# Altium schematic sheet Detection_Circuit_Sheet_01.SchDoc
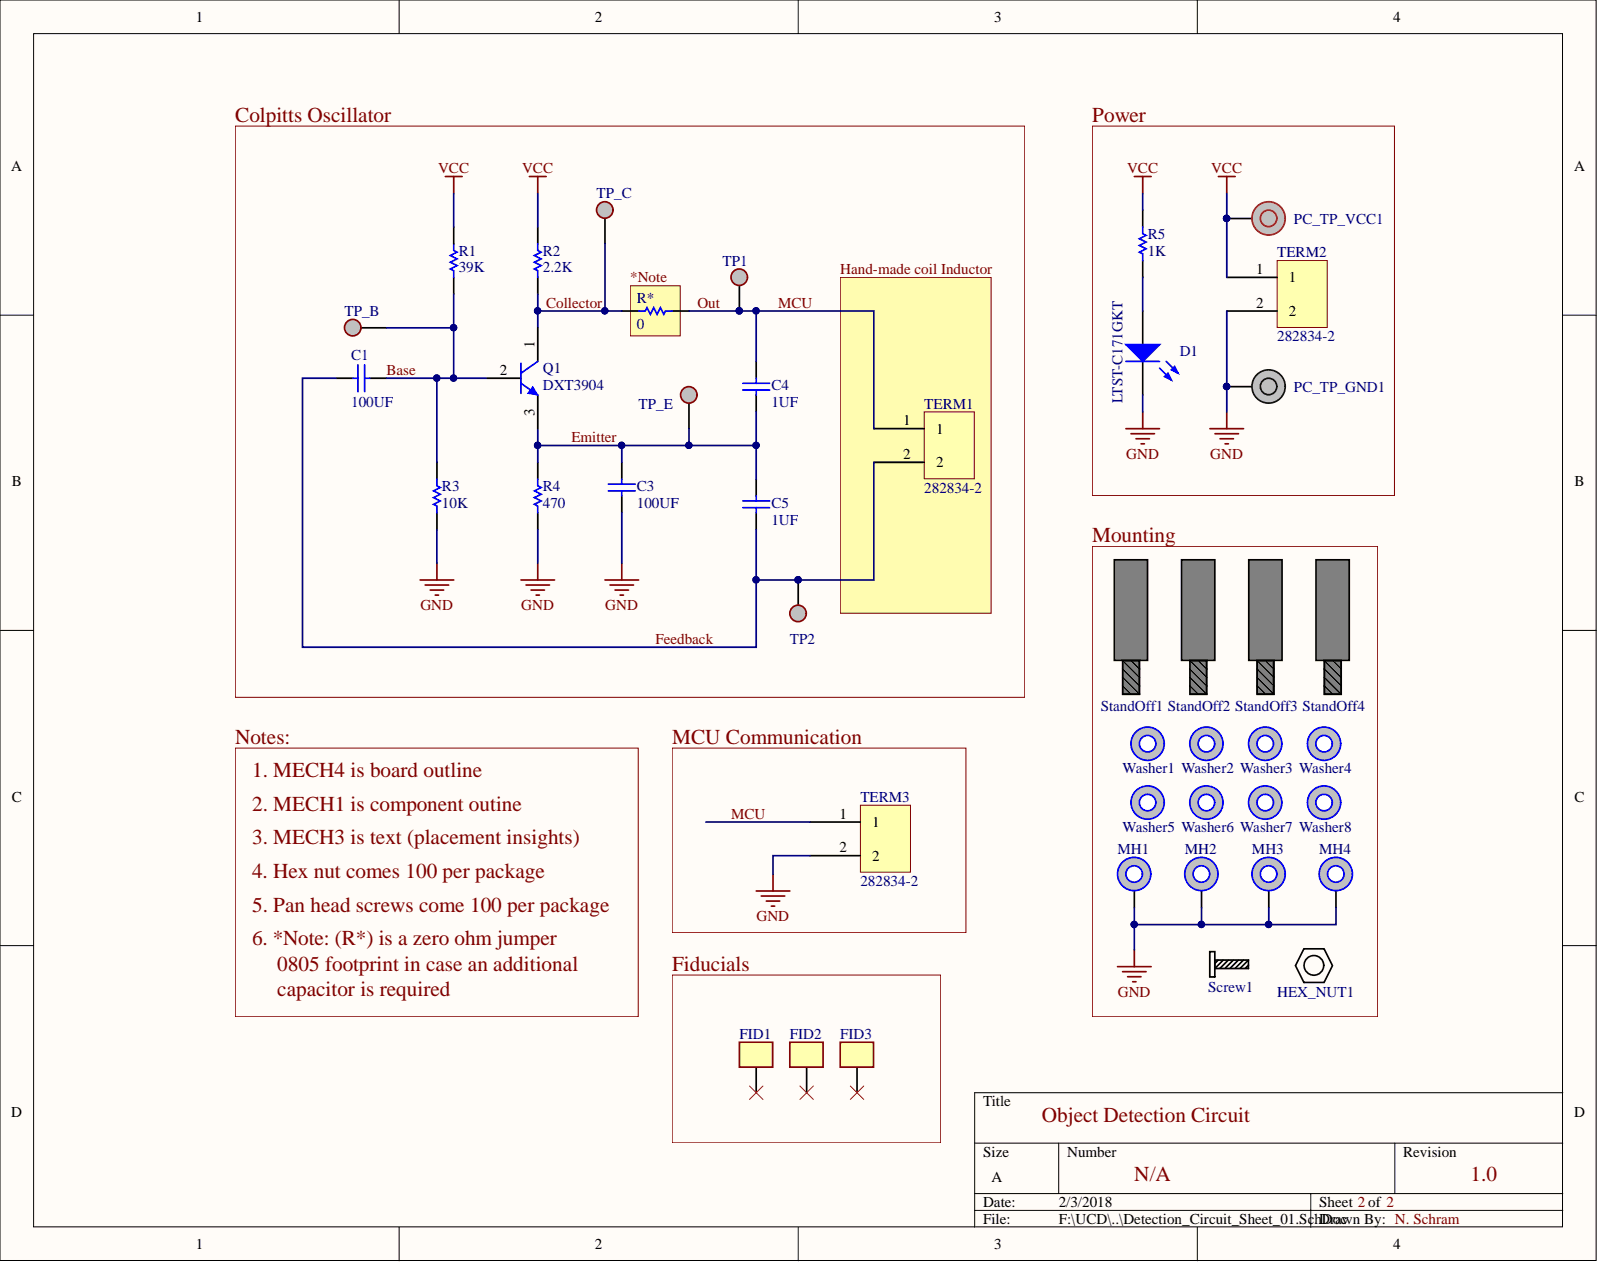
[diagram p0: Detection_Circuit_Sheet_01.SchDoc]

## Parts
C1  100UF  [C1210_M]
C3  100UF  [C1210_M]
C4  1UF  [6-0805_M]
C5  1UF  [6-0805_M]
D1  LTST-C171GKT  [6-0805_M]
FID1  Fiducial  [Fiducial]
FID2  Fiducial  [Fiducial]
FID3  Fiducial  [Fiducial]
HEX_NUT1  6-32 Hex Nut  (value not drawn)
MH1  #6 MH  [#6_MH]  (value not drawn)
MH2  #6 MH  [#6_MH]  (value not drawn)
MH3  #6 MH  [#6_MH]  (value not drawn)
MH4  #6 MH  [#6_MH]  (value not drawn)
PC_TP_GND1  PC Test Point GND  [PC Test Point GND]  (value not drawn)
PC_TP_VCC1  PC Test Point VCC  [PC Test Point VCC]  (value not drawn)
Q1  DXT3904  [DXT3904-13]
R1  39K  [6-0805_M]
R2  2.2K  [6-0805_M]
R3  10K  [6-0805_M]
R4  470  [6-0805_M]
R5  1K  [6-0805_M]
R*  0  [6-0805_M]
Screw1  6-32 Pan Head Screw  (value not drawn)
StandOff1  6-32 Stand Off  (value not drawn)
StandOff2  6-32 Stand Off  (value not drawn)
StandOff3  6-32 Stand Off  (value not drawn)
StandOff4  6-32 Stand Off  (value not drawn)
TERM1  282834-2  [282834-2]
TERM2  282834-2  [282834-2]
TERM3  282834-2  [282834-2]
TP1  TestPoint  [TestPoint]  (value not drawn)
TP2  TestPoint  [TestPoint]  (value not drawn)
TP_B  TestPoint  [TestPoint]  (value not drawn)
TP_C  TestPoint  [TestPoint]  (value not drawn)
TP_E  TestPoint  [TestPoint]  (value not drawn)
Washer1  Nylon Washer  (value not drawn)
Washer2  Nylon Washer  (value not drawn)
Washer3  Nylon Washer  (value not drawn)
Washer4  Nylon Washer  (value not drawn)
Washer5  Nylon Washer  (value not drawn)
Washer6  Nylon Washer  (value not drawn)
Washer7  Nylon Washer  (value not drawn)
Washer8  Nylon Washer  (value not drawn)

## Nets
GND: C3.1 D1.2 MH1.MH MH2.MH MH3.MH MH4.MH PC_TP_GND1.1 R3.1 R4.1 TERM2.2 TERM3.2
VCC: PC_TP_VCC1.1 R1.2 R2.2 R5.2 TERM2.1
Base: C1.2 Q1.2 R1.1 R3.2 TP_B.1
Collector: Q1.1 R2.1 R*.1 TP_C.1
Emitter: C3.2 C4.1 C5.2 Q1.3 R4.2 TP_E.1
Feedback: C1.1 C5.1 TERM1.2 TP2.1
MCU: C4.2 R*.2 TERM1.1 TERM3.1 TP1.1
NetD1_1: D1.1 R5.1

Not connected: FID1 FID; FID2 FID; FID3 FID

## Library data of the parts
C1 C3 = CC1210MKX5R7BB107: CAP CER 100UF 16V X5R 1210; Manufacturer: Yageo; Capacitance: 100µF; Tolerance: ±20%; Applications: General Purpose; Category: Capacitors; Component Kind: Standard; ComponentLink1Description: http://www.mouser.com/ds/2/447/Yageo_UPY-GP_NP0_16V-to-50V_9-358835.pdf; ComponentLink1URL: http://www.mouser.com/ds/2/447/Yageo_UPY-GP_NP0_16V-to-50V_9-358835.pdf; ComponentLink2Description: http://www.yageo.com/documents/recent/UPY-GPHC_X5R_4V-to-50V_25.pdf; ComponentLink2URL: http://www.yageo.com/documents/recent/UPY-GPHC_X5R_4V-to-50V_25.pdf; Family: Ceramic Capacitors; Features: -; Height - Seated (Max): -; Lead Spacing: -; Lead Style: -; Library Name: CC1210MKX5R7BB107.SchLib; Manufacturer Part Number: CC1210MKX5R7BB107; Mounting Type: Surface Mount, MLCC; Operating Temperature: -55°C ~ 85°C; Package / Case: 1210 (3225 Metric); Packaging: Tape & Reel (TR); Pricing 1: 1=1.82, 10=1.437, 100=1.0778 (USD); Ratings: -; Series: CC; Size / Dimension: 0.126" L x 0.098" W (3.20mm x 2.50mm); Stock 1: 19901; Supplier 1: Digi-Key; Supplier Part Number 1: 311-2052-1-ND; Temperature Coefficient: X5R; Thickness (Max): 0.110" (2.80mm); Voltage - Rated: 16V
C4 C5 = C0805C105J4RACTU: CAP CER 1UF 16V X7R 0805; Manufacturer: KEMET; Capacitance: 1µF; Online Catalog: X7R Dielectric (Commercial Grade); Other Names: 399-8001-6; Product Training Modules: Capacitor Basics- Typical Uses for Capacitors; Tolerance: ±5%; Applications: General Purpose; Category: Capacitors; Component Kind: Standard; ComponentLink1Description: http://components.arrow.com/part/dataview/30748390S8976888N7429; ComponentLink1URL: http://components.arrow.com/part/dataview/30748390S8976888N7429; ComponentLink2Description: http://www.mouser.com/ds/2/212/KEM_C1002_X7R_SMD-1102033.pdf; ComponentLink2URL: http://www.mouser.com/ds/2/212/KEM_C1002_X7R_SMD-1102033.pdf; ComponentLink3Description: http://www.farnell.com/datasheets/1828800.pdf; ComponentLink3URL: http://www.farnell.com/datasheets/1828800.pdf; ComponentLink4Description: http://www.farnell.com/datasheets/2064986.pdf; ComponentLink4URL: http://www.farnell.com/datasheets/2064986.pdf; ComponentLink5Description: http://capacitoredge.kemet.com/capedge2/DataSheet?pn=C0805C105J4RACTU; ComponentLink5URL: http://capacitoredge.kemet.com/capedge2/DataSheet?pn=C0805C105J4RACTU; ComponentLink6Description: https://search.kemet.com/component-edge/download/datasheet/C0805C105J4RACTU.pdf; ComponentLink6URL: https://search.kemet.com/component-edge/download/datasheet/C0805C105J4RACTU.pdf; Family: Ceramic Capacitors; Features: -; Height - Seated (Max): -; Lead Spacing: -; Lead Style: -; Library Name: C0805C105J4RACTU.SchLib; Manufacturer Part Number: C0805C105J4RACTU; Mounting Type: Surface Mount, MLCC; Operating Temperature: -55°C ~ 125°C; Package / Case: 0805 (2012 Metric); Packaging: Digi-Reel®; Pricing 1: 1=0.35, 10=0.241, 100=0.1364, 500=0.0963, 1000=0.0842 (USD); Ratings: -; Series: C; Size / Dimension: 0.079" L x 0.049" W (2.00mm x 1.25mm); Standard Package: 1; Stock 1: 96238; Supplier 1: Digi-Key; Supplier Part Number 1: 399-8001-1-ND; Temperature Coefficient: X7R; Thickness (Max): 0.039" (1.00mm); Voltage - Rated: 16V
D1 = LED3: Typical BLUE SiC LED; Manufacturer: Vishay Lite-On; Chip Material: GaP; DELETED: Compliant; Dominant Wave Length: 569 nm; Emitting Color: Yellow Green; Forward Current: 30 mA; Forward Voltage: 2.6 V; High Brightness: No; Luminous Intensity: 12mcd; Main Category: Chip LED; Number of  Elements: 1; Peak Wavelength: 565 nm; Power Dissipation: 100 W; Product Depth (mm): 1.25 mm; Product Height (mm): 0.8 mm; Product Length (mm): 2 mm; Reverse Voltage: 5 V; Shape Type: Rectangular; Test Current (It): 10 mA; Type: Uni-Color; Viewing Angle: 130 deg; Component Kind: Standard; ComponentLink1Description: https://datasheet.ciiva.com/2110/ltst-c171gkt-2110000.pdf; ComponentLink1URL: https://datasheet.ciiva.com/2110/ltst-c171gkt-2110000.pdf; ComponentLink2Description: https://datasheet.ciiva.com/2110/ltst-c171gkt-2110001.pdf; ComponentLink2URL: https://datasheet.ciiva.com/2110/ltst-c171gkt-2110001.pdf; ComponentLink3Description: https://datasheet.ciiva.com/2110/ltst-c171gkt-2110002.pdf; ComponentLink3URL: https://datasheet.ciiva.com/2110/ltst-c171gkt-2110002.pdf; ComponentLink4Description: https://datasheet.ciiva.com/16396/ltst-c171gkt-16396549.pdf; ComponentLink4URL: https://datasheet.ciiva.com/16396/ltst-c171gkt-16396549.pdf; ComponentLink5Description: https://datasheet.ciiva.com/11773/0900766b80dc0296-11773991.pdf; ComponentLink5URL: https://datasheet.ciiva.com/11773/0900766b80dc0296-11773991.pdf; ComponentLink6Description: https://datasheet.ciiva.com/12400/lite-on-ltst-c171gkt-190133-12400647.pdf; ComponentLink6URL: https://datasheet.ciiva.com/12400/lite-on-ltst-c171gkt-190133-12400647.pdf; Lens Dimensions: 1.25X1.4X0.5 mm; Library Name: Miscellaneous Devices.IntLib; Lum Intensity: 12mcd; Manufacturer Part Number: LTST-C171GKT; Mounting: Surface Mount; Operating Temperature Classification: Industrial; Operating Temp Range: -55C to 85C; Package Type: Chip Led; Packaging: Tape and Reel; Pin Count: 2; Pricing 1: 3000=0.0497, 6000=0.0432, 15000=0.0389, 30000=0.0346, 75000=0.0313, 150000=0.0292 (USD); Rad Hardened: No; Stock 1: 153000; Supplier 1: Digi-Key; Supplier Part Number 1: 160-1423-2-ND
HEX_NUT1 = HEX_NUT: HEX NUT 0.245" STEEL 6-32; Manufacturer Part Number: 4701; Brand: Keystone Electronics; Gender: Female; Plating: Zinc; Component Kind: Standard; ComponentLink1Description: https://datasheet.ciiva.com/41799/4701-41799174.pdf; ComponentLink1URL: https://datasheet.ciiva.com/41799/4701-41799174.pdf; ComponentLink2Description: https://datasheet.ciiva.com/18416/70181805-18416126.pdf; ComponentLink2URL: https://datasheet.ciiva.com/18416/70181805-18416126.pdf; Factory Pack Quantity: 100; Features: Universal Mounting Kits; Length: 6.22 mm; Library Name: 6-32_Hex_Nut.SchLib; Manufacturer: Keystone Electronics; Material: Steel; Pricing 1: 1=0.1, 10=0.049, 50=0.0342, 100=0.0293, 250=0.0283, 500=0.0244, 1000=0.0219, 2500=0.0195, 5000=0.0185 (USD); Product Category: Screws & Fasteners; RoHS: Details; Stock 1: 51671; Supplier 1: Digi-Key; Supplier Part Number 1: 36-4701-ND; Thickness: 2.41 mm; Thread Size: 6-32; Type: Nuts; Width: 6.22 mm
MH1-MH4 = MH: #6 Mounting Hole; Component Kind: Standard; Library Name: #6_MH.SchLib
PC_TP_GND1 = PC_TP_GND: TEST POINT PC MINI .040"D BLACK; Manufacturer: Keystone Electronics; Manufacturer Part Number: 5001; Alternate Color: 5004K-ND  - TEST POINT PC MINI .040"D YELLOW  5003K-ND  - TEST POINT PC MINI .040"D ORANGE  5002K-ND  - TEST POINT PC MINI .040"D WHITE  5000K-ND  - TEST POINT PC MINI .040"D RED; Catalog Drawings: 5000 Series; Kits: DKTGRS232C-KIT-ND  - TTL CONVERTER; Online Catalog: Color Coded Test Points; Other Names: 5001K; Category: Test and Measurement; Color: Black; Component Kind: Standard; ComponentLink1Description: https://datasheet.ciiva.com/27052/1581986-27052611.pdf; ComponentLink1URL: https://datasheet.ciiva.com/27052/1581986-27052611.pdf; ComponentLink2Description: https://datasheet.ciiva.com/16318/m60-2p60-16318423.pdf; ComponentLink2URL: https://datasheet.ciiva.com/16318/m60-2p60-16318423.pdf; ComponentLink3Description: https://datasheet.ciiva.com/16884/70182825-16884025.pdf; ComponentLink3URL: https://datasheet.ciiva.com/16884/70182825-16884025.pdf; ComponentLink4Description: https://datasheet.ciiva.com/22631/1703983-22631100.pdf; ComponentLink4URL: https://datasheet.ciiva.com/22631/1703983-22631100.pdf; ComponentLink5Description: https://datasheet.ciiva.com/11836/0900766b8009b7c7-11836045.pdf; ComponentLink5URL: https://datasheet.ciiva.com/11836/0900766b8009b7c7-11836045.pdf; Family: Test Points; Library Name: PC_TP_GND.SchLib; Material: Base, Nylon 46, UL 94V-0; Mounting Type: 0.040" (1.02mm) Hole Diameter; Packaging: Bulk; Pricing 1: 1=0.37, 10=0.316, 50=0.2298, 100=0.2209, 250=0.1983, 1000=0.1616, 2500=0.1442, 5000=0.1352 (USD); RoHS Information: RoHS Compliance Statement; Series: THM; Standard Package: 100; Stock 1: 888627; Supplier 1: Digi-Key; Supplier Part Number 1: 36-5001-ND; Terminal: 0.010" x 0.020" (0.25mm x 0.51mm) Phosphor Bronze Wire, Silver Plated; Type: PC Test Point, Miniature
PC_TP_VCC1 = PC_TP_VCC: TEST POINT PC MINI .040"D RED; Manufacturer: Keystone Electronics; Manufacturer Part Number: 5000; Alternate Color: 5004K-ND  - TEST POINT PC MINI .040"D YELLOW  5003K-ND  - TEST POINT PC MINI .040"D ORANGE  5002K-ND  - TEST POINT PC MINI .040"D WHITE  5001K-ND  - TEST POINT PC MINI .040"D BLACK; Catalog Drawings: 5000 Series; Online Catalog: Color Coded Test Points; Other Names: 5000K; Category: Test and Measurement; Color: Red; Component Kind: Standard; ComponentLink1Description: https://datasheet.ciiva.com/2917/5000-5004-2917627.pdf; ComponentLink1URL: https://datasheet.ciiva.com/2917/5000-5004-2917627.pdf; ComponentLink2Description: https://datasheet.ciiva.com/16883/70182825-16883965.pdf; ComponentLink2URL: https://datasheet.ciiva.com/16883/70182825-16883965.pdf; ComponentLink3Description: https://datasheet.ciiva.com/11836/0900766b8009b7c7-11836046.pdf; ComponentLink3URL: https://datasheet.ciiva.com/11836/0900766b8009b7c7-11836046.pdf; Family: Test Points; Library Name: PC_TP_VCC.SchLib; Material: Base, Nylon 46, UL 94V-0; Mounting Type: 0.040" (1.02mm) Hole Diameter; Packaging: Bulk; Pricing 1: 1=0.37, 10=0.316, 50=0.2298, 100=0.2209, 250=0.1983, 1000=0.1616, 2500=0.1442, 5000=0.1352 (USD); RoHS Information: RoHS Compliance Statement; Series: THM; Standard Package: 100; Stock 1: 652198; Supplier 1: Digi-Key; Supplier Part Number 1: 36-5000-ND; Terminal: 0.010" x 0.020" (0.25mm x 0.51mm) Phosphor Bronze Wire, Silver Plated; Type: PC Test Point, Miniature
Q1 = DXT3904-13: TRANS NPN 40V 0.2A SOT89-3; Manufacturer: Diodes; Brand: Diodes Incorporated; Collector- Base Voltage VCBO: 60 V; Collector-Emitter Saturation Voltage: 300 mV; Configuration: Single; DC Collector/Base Gain hfe Min: 30 at 100 mA, 1 V; Emitter- Base Voltage VEBO: 6 V; Gain Bandwidth Product fT: 300 MHz; Maximum DC Collector Current: 200 mA; Pd - Power Dissipation: 1000 mW; Unit Weight: 0.001834 oz; Collector- Emitter Voltage VCEO Max: 40 V; Component Kind: Standard; ComponentLink1Description: https://datasheet.ciiva.com/979/ds31141-979988.pdf; ComponentLink1URL: https://datasheet.ciiva.com/979/ds31141-979988.pdf; ComponentLink2Description: https://datasheet.ciiva.com/4724/ds31141-4724919.pdf; ComponentLink2URL: https://datasheet.ciiva.com/4724/ds31141-4724919.pdf; ComponentLink3Description: https://datasheet.ciiva.com/17303/ds31141-17303788.pdf; ComponentLink3URL: https://datasheet.ciiva.com/17303/ds31141-17303788.pdf; ComponentLink4Description: https://datasheet.ciiva.com/11206/ds31141-11206239.pdf; ComponentLink4URL: https://datasheet.ciiva.com/11206/ds31141-11206239.pdf; Factory Pack Quantity: 2500; Height: 1.5 mm; Length: 4.5 mm; Library Name: DXT3904-13.SchLib; Manufacturer Part Number: DXT3904-13; Maximum Operating Temperature: + 150 C; Minimum Operating Temperature: - 55 C; Mounting Style: SMD/SMT; Package / Case: SOT-89; Packaging: Reel; Pricing 1: 1=0.53, 10=0.426, 100=0.29, 500=0.2175, 1000=0.1632 (USD); Product Category: Bipolar Transistors - BJT; RoHS: True; Series: DXT3904; Stock 1: 10942; Supplier 1: Digi-Key; Supplier Part Number 1: DXT3904-13DICT-ND; Transistor Polarity: NPN; Width: 2.48 mm
R1 = ERJ-PB6D3902V: RES SMD 39K OHM 0.5% 1/4W 0805; Manufacturer: Panasonic; Power (Watts): 0.25W, 1/4W; Temperature Coefficient: ±50ppm/°C; Category: Resistors; Component Kind: Standard; ComponentLink1Description: https://industrial.panasonic.com/sa/system/files/data/download/catalog/id_fixed-resistors_e_2.pdf; ComponentLink1URL: https://industrial.panasonic.com/sa/system/files/data/download/catalog/id_fixed-resistors_e_2.pdf; Composition: Thick Film; Failure Rate: -; Family: Chip Resistor - Surface Mount; Features: Automotive AEC-Q200, Pulse Withstanding; Height - Seated (Max): 0.028" (0.70mm); Library Name: ERJ-PB6D3902V.SchLib; Manufacturer Part Number: ERJ-PB6D3902V; Number of Terminations: 2; Operating Temperature: -55°C ~ 155°C; Package / Case: 0805 (2012 Metric); Packaging: Tape & Reel (TR); Part Status: Active; Pricing 1: 1=0.24, 10=0.204, 25=0.1488, 50=0.1078, 100=0.0799, 250=0.0614, 500=0.0483, 1000=0.0335 (USD); Resistance (Ohms): 39k; Series: ERJ; Size / Dimension: 0.079" L x 0.049" W (2.00mm x 1.25mm); Stock 1: 2236; Supplier 1: Digi-Key; Supplier Device Package: 0805; Supplier Part Number 1: P21199CT-ND; Tolerance: ±0.5%
R2 = ERJ-PB6D2201V: RES SMD 2.2K OHM 0.5% 1/4W 0805; Manufacturer: Panasonic; Power (Watts): 0.25W, 1/4W; Temperature Coefficient: ±50ppm/°C; Category: Resistors; Component Kind: Standard; ComponentLink1Description: https://industrial.panasonic.com/sa/system/files/data/download/catalog/id_fixed-resistors_e_2.pdf; ComponentLink1URL: https://industrial.panasonic.com/sa/system/files/data/download/catalog/id_fixed-resistors_e_2.pdf; Composition: Thick Film; Failure Rate: -; Family: Chip Resistor - Surface Mount; Features: Automotive AEC-Q200, Pulse Withstanding; Height - Seated (Max): 0.028" (0.70mm); Library Name: ERJ-PB6D2201V.SchLib; Manufacturer Part Number: ERJ-PB6D2201V; Number of Terminations: 2; Operating Temperature: -55°C ~ 155°C; Package / Case: 0805 (2012 Metric); Packaging: Tape & Reel (TR); Part Status: Active; Pricing 1: 1=0.24, 10=0.204, 25=0.1488, 50=0.1078, 100=0.0799, 250=0.0614, 500=0.0483, 1000=0.0335 (USD); Resistance (Ohms): 2.2k; Series: ERJ; Size / Dimension: 0.079" L x 0.049" W (2.00mm x 1.25mm); Stock 1: 3147; Supplier 1: Digi-Key; Supplier Device Package: 0805; Supplier Part Number 1: P21055CT-ND; Tolerance: ±0.5%
R3 = RNCP0805FTD10K0: RES SMD 10K OHM 1% 1/4W 0805; Manufacturer: Stackpole Electronics; Power (Watts): 0.25W, 1/4W; Temperature Coefficient: ±100ppm/°C; Category: Resistors; Component Kind: Standard; ComponentLink1Description: http://www.seielect.com/Catalog/SEI-rncp.pdf; ComponentLink1URL: http://www.seielect.com/Catalog/SEI-rncp.pdf; Composition: Thin Film; Family: Chip Resistor - Surface Mount; Features: Anti-Sulfur, Moisture Resistant; Height: 0.024" (0.60mm); Library Name: RNCP0805FTD10K0.SchLib; Manufacturer Part Number: RNCP0805FTD10K0; Number of Terminations: 2; Operating Temperature: -55°C ~ 155°C; Package / Case: 0805 (2012 Metric); Packaging: Tape & Reel (TR); Pricing 1: 1=0.1, 10=0.037, 100=0.015, 1000=0.0067, 2500=0.0058 (USD); Resistance (Ohms): 10k; Series: RNCP; Size / Dimension: 0.079" L x 0.049" W (2.00mm x 1.25mm); Stock 1: 103823; Supplier 1: Digi-Key; Supplier Device Package: 0805; Supplier Part Number 1: RNCP0805FTD10K0CT-ND; Tolerance: ±1%
R4 = ERJ-PB6D4700V: RES SMD 470 OHM 0.5% 1/4W 0805; Manufacturer: Panasonic; Power (Watts): 0.25W, 1/4W; Temperature Coefficient: ±50ppm/°C; Category: Resistors; Component Kind: Standard; ComponentLink1Description: https://industrial.panasonic.com/sa/system/files/data/download/catalog/id_fixed-resistors_e_2.pdf; ComponentLink1URL: https://industrial.panasonic.com/sa/system/files/data/download/catalog/id_fixed-resistors_e_2.pdf; Composition: Thick Film; Failure Rate: -; Family: Chip Resistor - Surface Mount; Features: Automotive AEC-Q200, Pulse Withstanding; Height - Seated (Max): 0.028" (0.70mm); Library Name: ERJ-PB6D4700V.SchLib; Manufacturer Part Number: ERJ-PB6D4700V; Number of Terminations: 2; Operating Temperature: -55°C ~ 155°C; Package / Case: 0805 (2012 Metric); Packaging: Cut Tape (CT); Part Status: Active; Pricing 1: 1=0.24, 10=0.204, 25=0.1488, 50=0.1078, 100=0.0799, 250=0.0614, 500=0.0483, 1000=0.0335 (USD); Resistance (Ohms): 470; Series: ERJ; Size / Dimension: 0.079" L x 0.049" W (2.00mm x 1.25mm); Stock 1: 2688; Supplier 1: Digi-Key; Supplier Device Package: 0805; Supplier Part Number 1: P20981CT-ND; Tolerance: ±0.5%
R5 = RNCP0805FTD1K00: RES SMD 1K OHM 1% 1/4W 0805; Manufacturer: Stackpole Electronics; Power (Watts): 0.25W, 1/4W; Temperature Coefficient: ±100ppm/°C; Category: Resistors; Component Kind: Standard; ComponentLink1Description: http://www.seielect.com/Catalog/SEI-rncp.pdf; ComponentLink1URL: http://www.seielect.com/Catalog/SEI-rncp.pdf; Composition: Thin Film; Family: Chip Resistor - Surface Mount; Features: Anti-Sulfur, Moisture Resistant; Height: 0.024" (0.60mm); Library Name: RNCP0805FTD1K00.SchLib; Manufacturer Part Number: RNCP0805FTD1K00; Number of Terminations: 2; Operating Temperature: -55°C ~ 155°C; Package / Case: 0805 (2012 Metric); Packaging: Tape & Reel (TR); Pricing 1: 1=0.1, 10=0.037, 100=0.015, 1000=0.0067, 2500=0.0058 (USD); Resistance (Ohms): 1k; Series: RNCP; Size / Dimension: 0.079" L x 0.049" W (2.00mm x 1.25mm); Stock 1: 107354; Supplier 1: Digi-Key; Supplier Device Package: 0805; Supplier Part Number 1: RNCP0805FTD1K00CT-ND; Tolerance: ±1%
R* = ERJ-6GEY0R00V: RES SMD 0 OHM JUMPER 1/8W 0805; Manufacturer: Panasonic ECG; Rated Power: 0.125 W; Resistance: 0 O; Temp Coefficient: 0 ppm/°C; Tolerance (%): 0 %; Component Kind: Standard; ComponentLink1Description: https://datasheet.ciiva.com/28695/1678796-28695877.pdf; ComponentLink1URL: https://datasheet.ciiva.com/28695/1678796-28695877.pdf; ComponentLink2Description: https://datasheet.ciiva.com/11821/0900766b80f806dd-11821735.pdf; ComponentLink2URL: https://datasheet.ciiva.com/11821/0900766b80f806dd-11821735.pdf; ComponentLink3Description: https://datasheet.ciiva.com/43460/1678796-43460073.pdf; ComponentLink3URL: https://datasheet.ciiva.com/43460/1678796-43460073.pdf; ComponentLink4Description: https://datasheet.ciiva.com/9117/aoa0000ce1-9117354.pdf; ComponentLink4URL: https://datasheet.ciiva.com/9117/aoa0000ce1-9117354.pdf; ComponentLink5Description: https://datasheet.ciiva.com/46377/1678796-46377866.pdf; ComponentLink5URL: https://datasheet.ciiva.com/46377/1678796-46377866.pdf; ComponentLink6Description: https://datasheet.ciiva.com/36526/1989607-36526237.pdf; ComponentLink6URL: https://datasheet.ciiva.com/36526/1989607-36526237.pdf; ComponentLink7Description: https://datasheet.ciiva.com/17215/aoa0000ce1-17215390.pdf; ComponentLink7URL: https://datasheet.ciiva.com/17215/aoa0000ce1-17215390.pdf; ComponentLink8Description: https://datasheet.ciiva.com/16512/aoa0000ce1-16512111.pdf; ComponentLink8URL: https://datasheet.ciiva.com/16512/aoa0000ce1-16512111.pdf; Library Name: ERJ-6GEY0R00V.SchLib; Manufacturer Part Number: ERJ-6GEY0R00V; Pricing 1: 1=0.1, 10=0.053, 25=0.038, 50=0.029, 100=0.0214, 250=0.0163, 500=0.0131, 1000=0.0096 (USD); Size Code: 0805; Stock 1: 6840904; Supplier 1: Digi-Key; Supplier Part Number 1: P0.0ACT-ND
Screw1 = 6-32 Screw: MACHINE SCREW PAN PHILLIPS 6-32; Manufacturer: B&F Fastener; Manufacturer Part Number: PMSSS6320050PH; Dynamic Catalog: Stainless Steel Screws; Head Height: 0.092" (2.34mm); Kits: 1608-KIT-ND  - STAINLESS STL HARDWARE #6,#8,#10; Other Names: H711; Category: Hardware, Fasteners, Accessories; Component Kind: Standard; ComponentLink1Description: https://datasheet.ciiva.com/1034/machinepancombo-1034179.pdf; ComponentLink1URL: https://datasheet.ciiva.com/1034/machinepancombo-1034179.pdf; Drive Type: Phillips; Family: Screws, Bolts; Length - Below Head: 0.500" (12.70mm) 1/2"; Length - Overall: 0.592" (15.04mm); Library Name: 6-32_Screw.SchLib; Material: Stainless Steel; Pricing 1: 100=0.0773, 1000=0.0618 (USD); Screw Head Type: Pan Head; Standard Package: 100; Stock 1: 15276; Supplier 1: Digi-Key; Supplier Part Number 1: H711-ND; Thread Size: 6-32; Type: Machine Screw
StandOff1-StandOff4 = STANDOFF: HEX STANDOFF 6-32 ALUMINUM 3/4"; Manufacturer: Keystone Electronics; Manufacturer Part Number: 8416; Between Board Height: 0.750" (19.05mm) 3/4"; Gender: Male, Female; Plating: Iridite; Screw, Thread Size: #6-32; Threaded/Unthreaded: Threaded; Category: Hardware, Fasteners, Accessories; Color: -; Component Kind: Standard; ComponentLink1Description: https://datasheet.ciiva.com/5225/m60-2p70-311182-5225146.pdf; ComponentLink1URL: https://datasheet.ciiva.com/5225/m60-2p70-311182-5225146.pdf; ComponentLink2Description: https://datasheet.ciiva.com/14533/70181845-14533167.pdf; ComponentLink2URL: https://datasheet.ciiva.com/14533/70181845-14533167.pdf; Diameter - Inside: -; Diameter - Outside: 0.250" (6.35mm) 1/4" Hex; Family: Board Spacers, Standoffs; Features: -; Length - Overall: 1.000" (25.40mm) 1"; Library Name: 6-32_Stand_Off.SchLib; Material: Aluminum; Pricing 1: 1=0.74, 10=0.55, 50=0.44, 100=0.4217, 250=0.385, 1000=0.3079, 2500=0.2751, 5000=0.2659 (USD); Series: -; Stock 1: 20629; Supplier 1: Digi-Key; Supplier Part Number 1: 36-8416-ND; Type: Hex Standoff; Weight: -
TERM1-TERM3 = 282834-2: TERM BLOCK 2POS SIDE ENT 2.54MM; Manufacturer: TE Connectivity; 3D Model: 282834-2.pdf; Clamp Material - Plating: Brass - Nickel Plated; Current: 10A; Dynamic Catalog: Buchanan Series; Featured Product: Eurostyle Terminal Block Connectors; Mating Orientation: Horizontal with Board; Number of Levels: 1; Other Names: 282834-2-ND  A98333; Pitch: 0.100" (2.54mm); Positions Per Level: 2; Product Training Modules: Wire-to-Board Eurostyle Terminal Blocks; Screw Material - Plating: Steel, Stainless; Screw Thread: M1.6; Torque - Screw: 0.2 Nm (1.7 Lb-In); Voltage: 150V; Wire Gauge: 16-30 AWG; Wire Termination: Screw - Rising Cage Clamp; Category: Connectors, Interconnects; Color: Green; Component Kind: Standard; ComponentLink1Description: https://datasheet.ciiva.com/11758/0900766b80e7dc96-11758675.pdf; ComponentLink1URL: https://datasheet.ciiva.com/11758/0900766b80e7dc96-11758675.pdf; ComponentLink2Description: https://datasheet.ciiva.com/14609/70086732-14609670.pdf; ComponentLink2URL: https://datasheet.ciiva.com/14609/70086732-14609670.pdf; ComponentLink3Description: https://datasheet.ciiva.com/15169/getdatasheetpartid-155864-15169558.pdf; ComponentLink3URL: https://datasheet.ciiva.com/15169/getdatasheetpartid-155864-15169558.pdf; ComponentLink4Description: https://datasheet.ciiva.com/3141/eng-cs-1308389-eurostyle-terminal-blocks-0607-3141115.pdf; ComponentLink4URL: https://datasheet.ciiva.com/3141/eng-cs-1308389-eurostyle-terminal-blocks-0607-3141115.pdf; ComponentLink5Description: https://datasheet.ciiva.com/16386/282834-2-16386363.pdf; ComponentLink5URL: https://datasheet.ciiva.com/16386/282834-2-16386363.pdf; ComponentLink6Description: https://datasheet.ciiva.com/823/eng-cd-282834-c1-823453.pdf; ComponentLink6URL: https://datasheet.ciiva.com/823/eng-cd-282834-c1-823453.pdf; ComponentLink7Description: https://datasheet.ciiva.com/16676/eng-cs-1308389-eurostyle-terminal-blocks-0607-16676126.pdf; ComponentLink7URL: https://datasheet.ciiva.com/16676/eng-cs-1308389-eurostyle-terminal-blocks-0607-16676126.pdf; ComponentLink8Description: https://datasheet.ciiva.com/30166/eng-cs-1308389-eurostyle-terminal-blocks-0607-30166974.pdf; ComponentLink8URL: https://datasheet.ciiva.com/30166/eng-cs-1308389-eurostyle-terminal-blocks-0607-30166974.pdf; Contact Material - Plating: Brass - Tin Plated; Family: Terminal Blocks - Wire to Board; Housing Material: Polyamide (PA66), Nylon 6/6; Library Name: 282834-2.SchLib; Manufacturer Part Number: 282834-2; Mounting Type: Through Hole; Operating Temperature: -40°C ~ 105°C; Packaging: Bulk; Pricing 1: 1=0.96, 10=0.674, 25=0.6288, 50=0.5708, 100=0.5682, 250=0.4798, 500=0.4546, 1000=0.4041, 5000=0.3788 (USD); RoHS Information: 282834-2 Statement of Compliance; Series: Buchanan; Standard Package: 300; Stock 1: 10622; Supplier 1: Digi-Key; Supplier Part Number 1: A98333-ND
TP1 TP2 TP_B TP_C TP_E = TestPoint: Test Point; Component Kind: Standard; Library Name: TestPoint.SchLib
Washer1-Washer8 = Washer: MACHINE SCREW PAN PHILLIPS 6-32; Manufacturer: Keystone Electronics; Manufacturer Part Number: 3349; Catalog Drawings: Flat Washer Side; Dynamic Catalog: Nylon and Fiber Flat Washers; Other Names: 3349K; Thread/Screw/Hole Size: #6; Category: Hardware, Fasteners, Accessories; Component Kind: Standard; ComponentLink1Description: https://datasheet.ciiva.com/16215/m60-2p131-16215696.pdf; ComponentLink1URL: https://datasheet.ciiva.com/16215/m60-2p131-16215696.pdf; Diameter - Inside: 0.140" (3.56mm); Diameter - Outside: 0.312" (7.92mm); Family: Washers; Library Name: #6_Nylon_Washer.SchLib; Material: Nylon; Pricing 1: 10=0.064, 50=0.0564, 100=0.0393, 250=0.0339, 500=0.0325, 1000=0.0285, 2500=0.0257, 5000=0.0244 (USD); Standard Package: 100; Stock 1: 26447; Supplier 1: Digi-Key; Supplier Part Number 1: 3349K-ND; Thickness: 0.032" (0.81mm); Type: Flat
FID1-FID3 = Fiducial: Component Kind: Standard; Description: Fiducial; Library Name: Fiducial.SchLib
